# Altium schematic sheet PID.SchDoc(PID)
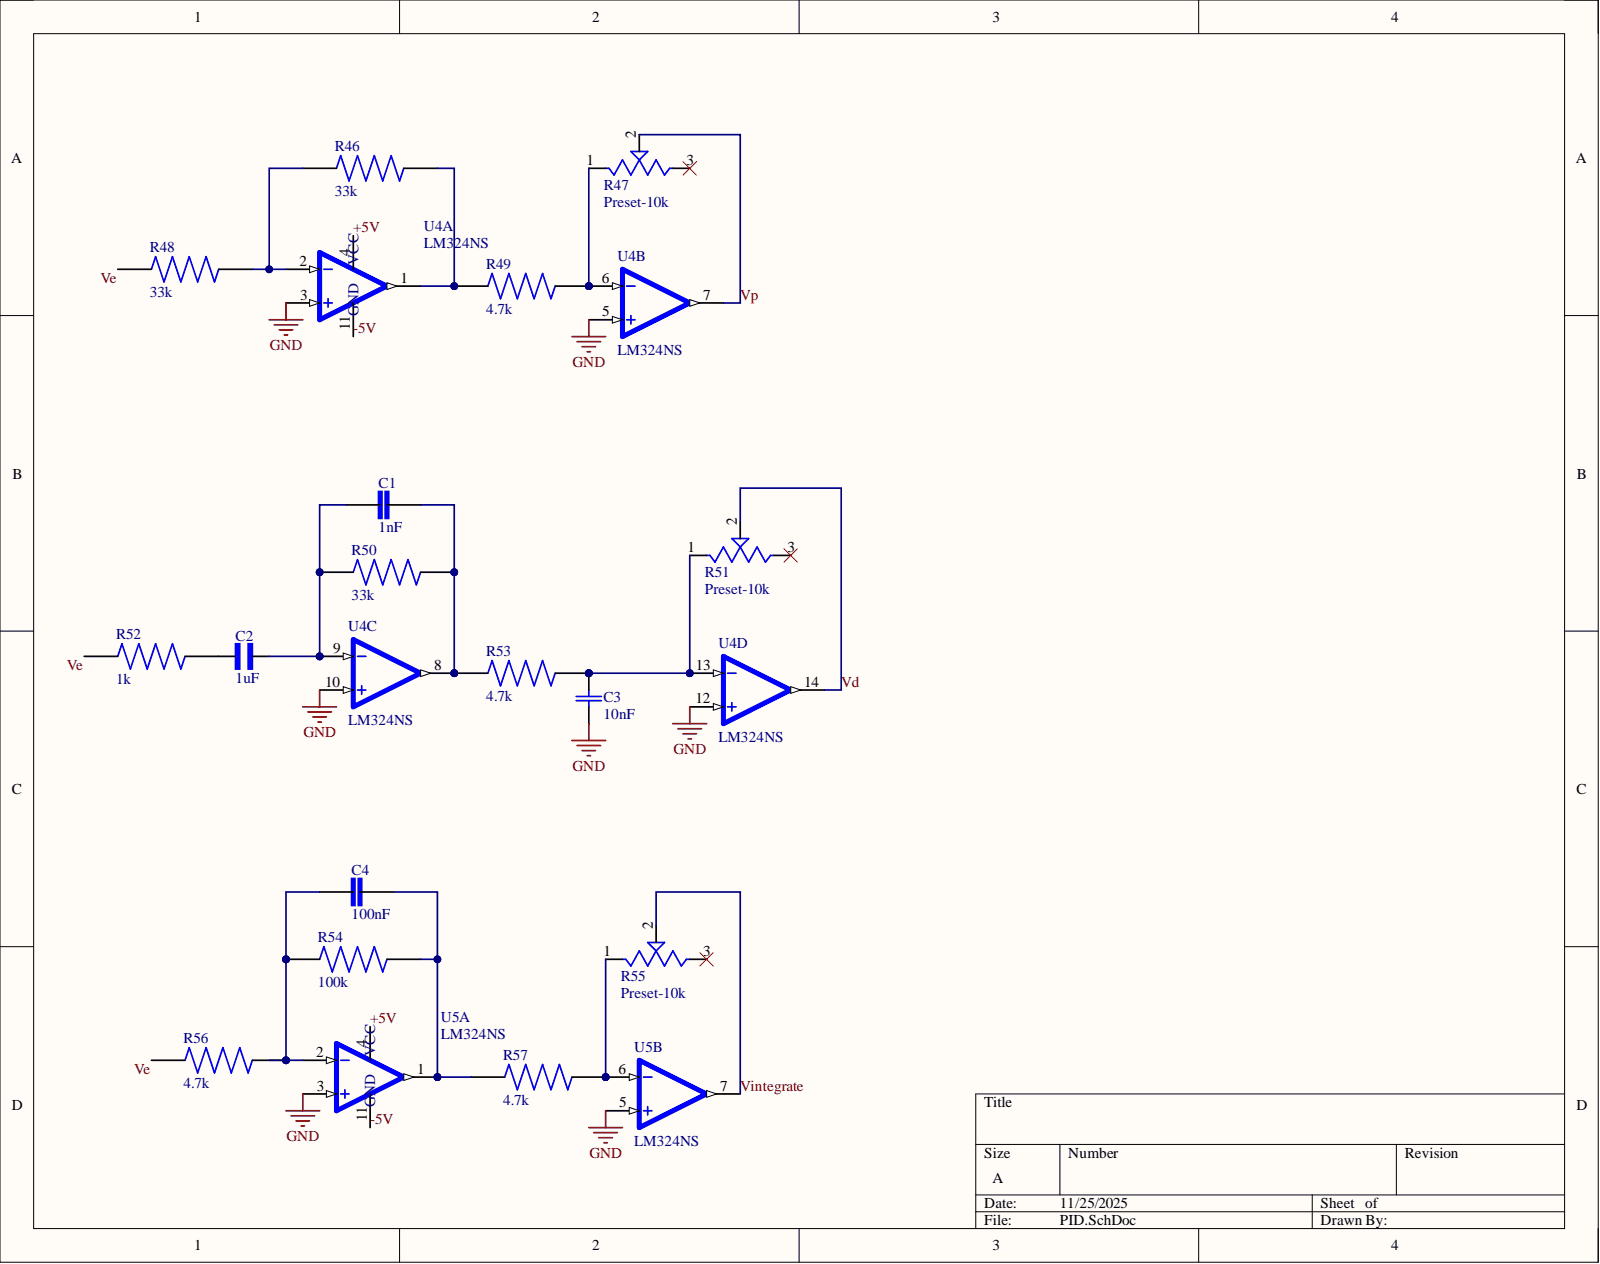
[diagram p0: PID.SchDoc]

## Parts
C1  1nF
C2  1uF
C3  10nF
C4  100nF
R46  33k
R47  Preset-10k
R48  33k
R49  4.7k
R50  33k
R51  Preset-10k
R52  1k
R53  4.7k
R54  100k
R55  Preset-10k
R56  4.7k
R57  4.7k
U4  LM324NS  (parts A,B,C,D)
U5  LM324NS  (parts A,B)

## Nets
GND: C3.1 U4.3 U4.5 U4.10 U4.12 U5.3 U5.5
+5V: U4.4 U5.4
-5V: U4.11 U5.11
Vd: R51.2 U4.14
Ve: R48.1 R52.1 R56.1
Vintegrate: R55.2 U5.7
Vp: R47.2 U4.7
NetC1_1: C1.1 C2.1 R50.1 U4.9
NetC1_2: C1.2 R50.2 R53.1 U4.8
NetC2_2: C2.2 R52.2
NetC3_2: C3.2 R51.1 R53.2 U4.13
NetC4_1: C4.1 R54.1 R56.2 U5.2
NetC4_2: C4.2 R54.2 R57.1 U5.1
NetR46_1: R46.1 R48.2 U4.2
NetR46_2: R46.2 R49.1 U4.1
NetR47_1: R47.1 R49.2 U4.6
NetR55_1: R55.1 R57.2 U5.6

Not connected: R47 3; R51 3; R55 3

## Library data of the parts
C1 C4 = 1nF: 1000 pF Â±10% 50V Ceramic Capacitor X7R 0805 (2012 Metric); CC0805KRX7R9BB102; MF: Yageo; Check_prices: https://www.snapeda.com/parts/CC0805KRX7R9BB102/Yageo/view-part/?ref=eda; Component Kind: Standard; MP: CC0805KRX7R9BB102; Package: 0805 Yageo; SnapEDA_Link: https://www.snapeda.com/parts/CC0805KRX7R9BB102/Yageo/view-part/?ref=snap; Library Name: 1nfs.IntLib
C2 = 1uF: SMD Capacitor X7R(EIA) with Capacitance: 1.0uF Tol. 10%. Rated Voltage: 25Vdc; GRM21BR71E105KA99L; MF: Murata; Check_prices: https://www.snapeda.com/parts/GRM21BR71E105KA99L/Murata/view-part/?ref=eda; Component Kind: Standard; MP: GRM21BR71E105KA99L; Package: 2012 Murata; SnapEDA_Link: https://www.snapeda.com/parts/GRM21BR71E105KA99L/Murata/view-part/?ref=snap; Library Name: 1ufs.IntLib
C3 = 10nF: 10000 pF Â±10% 50V Ceramic Capacitor X7R 0805 (2012 Metric); CC0805KRX7R9BB103; MF: Yageo; Check_prices: https://www.snapeda.com/parts/CC0805KRX7R9BB103/Yageo/view-part/?ref=eda; Component Kind: Standard; MP: CC0805KRX7R9BB103; Package: 0805 Yageo; SnapEDA_Link: https://www.snapeda.com/parts/CC0805KRX7R9BB103/Yageo/view-part/?ref=snap; Library Name: 10nfs.IntLib
R46 R48 R50 = 33k: 33 kOhms Â±1% 0.125W, 1/8W Chip Resistor 0805 (2012 Metric) Moisture Resistant Thick Film; RC0805FR-0733KL; MF: Yageo; Check_prices: https://www.snapeda.com/parts/RC0805FR-0733KL/Yageo/view-part/?ref=eda; Component Kind: Standard; Library Name: 33ks.IntLib; MP: RC0805FR-0733KL; Package: 2012 Yageo; SnapEDA_Link: https://www.snapeda.com/parts/RC0805FR-0733KL/Yageo/view-part/?ref=snap
R47 R51 R55 = Preset: TRIMMER - 6MM ROUND, SINGLE-TURN,CERMET, 10K OHMS | Bourns 3306F-1-103; Check_prices: https://www.snapeda.com/parts/3306F-1-103/Bourns/view-part/?ref=eda; Component Kind: Standard; Library Name: 3306F-1-103.IntLib; MF: Bourns; MP: 3306F-1-103; Package: 3306F Bourns; SnapEDA_Link: https://www.snapeda.com/parts/3306F-1-103/Bourns/view-part/?ref=snap
R49 R53 R56 R57 = 4.7k: 4.7 kOhms Â±1% 0.125W, 1/8W Chip Resistor 0805 (2012 Metric) Moisture Resistant Thick Film; RC0805FR-074K7L; MF: Yageo; Availability: In Stock; Check_prices: https://www.snapeda.com/parts/RC0805FR-074K7L/Yageo/view-part/?ref=eda; Component Kind: Standard; Library Name: 4 7ks.IntLib; MP: RC0805FR-074K7L; Package: 2012 Rohm Semiconductor; Price: None; Purchase-URL: https://pricing.snapeda.com/search/part/RC0805FR-074K7L/?ref=eda; SnapEDA_Link: https://www.snapeda.com/parts/RC0805FR-074K7L/Yageo/view-part/?ref=snap
R52 = 1k: 1 kOhms Â±1% 0.125W, 1/8W Chip Resistor 0805 (2012 Metric) Moisture Resistant Thick Film; RC0805FR-071KL; MF: Yageo; Check_prices: https://www.snapeda.com/parts/RC0805FR-071KL/Yageo/view-part/?ref=eda; Component Kind: Standard; DigiKey_Part_Number: 311-1.00KCRTR-ND; Library Name: 1ks.IntLib; MP: RC0805FR-071KL; Package: 2012 Yageo; Purchase-URL: https://pricing.snapeda.com/search?q=RC0805FR-071KL&ref=eda; SnapEDA_Link: https://www.snapeda.com/parts/RC0805FR-071KL/Yageo/view-part/?ref=snap
R54 = 100k: 100 kOhms Â±1% 0.125W, 1/8W Chip Resistor 0805 (2012 Metric) Moisture Resistant Thick Film; RC0805FR-07100KL; MF: Yageo; Check_prices: https://www.snapeda.com/parts/RC0805FR-07100KL/Yageo/view-part/?ref=eda; Component Kind: Standard; Library Name: 100ks.IntLib; MP: RC0805FR-07100KL; Package: 2012 Yageo; SnapEDA_Link: https://www.snapeda.com/parts/RC0805FR-07100KL/Yageo/view-part/?ref=snap
U4 U5 = LM324NS: 4-Channel industry standard operational amplifier 14-SO 0 to 70; LM324NSRG4; MF: Texas Instruments; Availability: In Stock; Check_prices: https://www.snapeda.com/parts/LM324NSRG4/Texas+Instruments/view-part/?ref=eda; Component Kind: Standard; Library Name: LM324NSRG4.IntLib; MP: LM324NSRG4; Package: SOP-14 Texas Instruments; Price: None; Purchase-URL: https://pricing.snapeda.com/search/part/LM324NSRG4/?ref=eda; SnapEDA_Link: https://www.snapeda.com/parts/LM324NSRG4/Texas+Instruments/view-part/?ref=snap
